# Altium schematic sheet InputsExtras.SchDoc
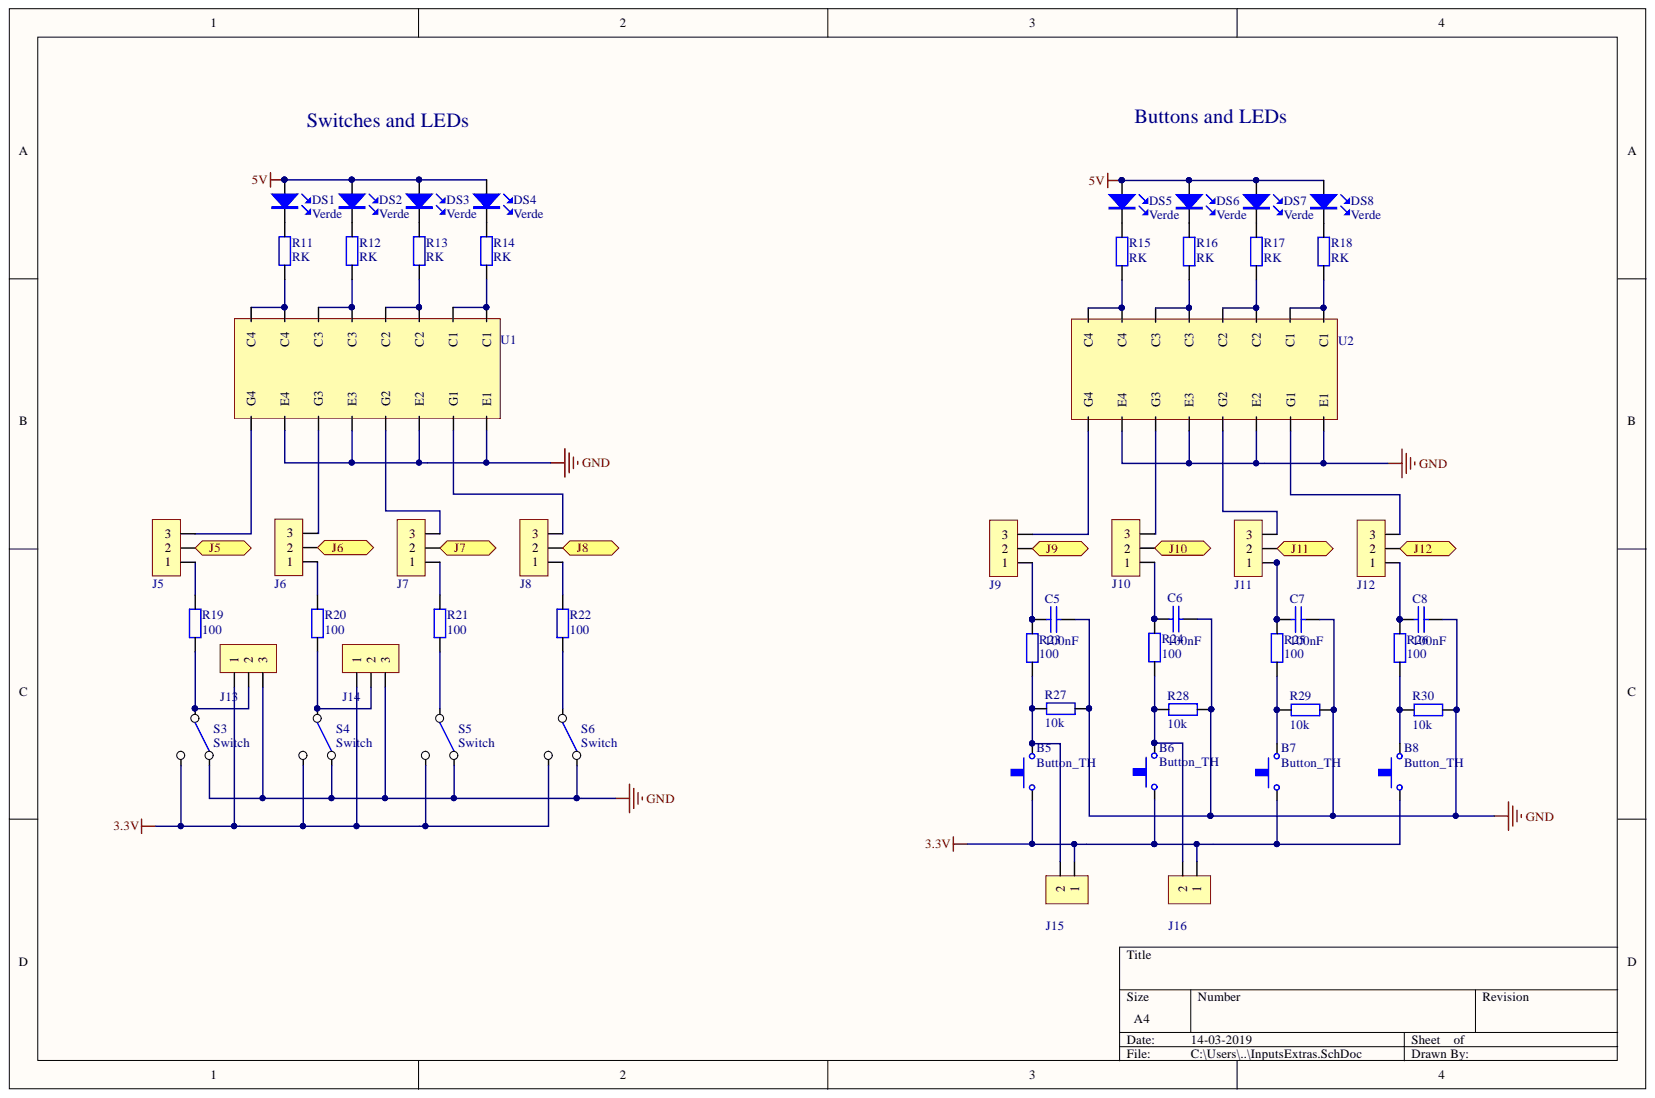
[diagram p0: InputsExtras.SchDoc]

## Parts
B5  Button_TH  [ButtonTH]
B6  Button_TH  [ButtonTH]
B7  Button_TH  [ButtonTH]
B8  Button_TH  [ButtonTH]
C5  100nF  [1206]
C6  100nF  [1206]
C7  100nF  [1206]
C8  100nF  [1206]
DS1  Verde  [LED_100mil]
DS2  Verde  [LED_100mil]
DS3  Verde  [LED_100mil]
DS4  Verde  [LED_100mil]
DS5  Verde  [LED_100mil]
DS6  Verde  [LED_100mil]
DS7  Verde  [LED_100mil]
DS8  Verde  [LED_100mil]
J5  Cleva 2 vias  [con_3_100milis]  (value not drawn)
J6  Cleva 2 vias  [con_3_100milis]  (value not drawn)
J7  Cleva 2 vias  [con_3_100milis]  (value not drawn)
J8  Cleva 2 vias  [con_3_100milis]  (value not drawn)
J9  Cleva 2 vias  [con_3_100milis]  (value not drawn)
J10  Cleva 2 vias  [con_3_100milis]  (value not drawn)
J11  Cleva 2 vias  [con_3_100milis]  (value not drawn)
J12  Cleva 2 vias  [con_3_100milis]  (value not drawn)
J13  Cleva 2 vias  [Con3_KK_256]  (value not drawn)
J14  Cleva 2 vias  [Con3_KK_256]  (value not drawn)
J15  Cleva 2 vias  [Con2_KK_256]  (value not drawn)
J16  Cleva 2 vias  [Con2_KK_256]  (value not drawn)
R11  RK  [1206]
R12  RK  [1206]
R13  RK  [1206]
R14  RK  [1206]
R15  RK  [1206]
R16  RK  [1206]
R17  RK  [1206]
R18  RK  [1206]
R19  100  [1206]
R20  100  [1206]
R21  100  [1206]
R22  100  [1206]
R23  100  [1206]
R24  100  [1206]
R25  100  [1206]
R26  100  [1206]
R27  10k  [1206]
R28  10k  [1206]
R29  10k  [1206]
R30  10k  [1206]
S3  Switch  [SwitchTH_2Pos]
S4  Switch  [SwitchTH_2Pos]
S5  Switch  [SwitchTH_2Pos]
S6  Switch  [SwitchTH_2Pos]
U1  MMPQ3904  [SO-16]  (value not drawn)
U2  MMPQ3904  [SO-16]  (value not drawn)

## Ports
J5, J6, J7, J8, J9, J10, J11, J12

## Nets
3.3V: B5.1 B6.1 B7.1 B8.1 J13.1 J14.1 J15.1 J16.1 S3.2 S4.2 S5.2 S6.2
5V: DS1.A DS2.A DS3.A DS4.A DS5.A DS6.A DS7.A DS8.A
GND: C5.1 C6.1 C7.1 C8.1 J13.3 J14.3 R27.1 R28.1 R29.1 R30.1 S3.0 S4.0 S5.0 S6.0 U1.10(E4) U1.12(E3) U1.14(E2) U1.16(E1) U2.10(E4) U2.12(E3) U2.14(E2) U2.16(E1)
J5: J5.2 ; port J5
J6: J6.2 ; port J6
J7: J7.2 ; port J7
J8: J8.2 ; port J8
J9: J9.2 ; port J9
J10: J10.2 ; port J10
J11: J11.2 ; port J11
J12: J12.2 ; port J12
NetB5_0: B5.0 J15.2 R23.1 R27.2
NetB6_0: B6.0 J16.2 R24.1 R28.2
NetB7_0: B7.0 R25.1 R29.2
NetB8_0: B8.0 R26.1 R30.2
NetC5_2: C5.2 J9.1 R23.2
NetC6_2: C6.2 J10.1 R24.2
NetC7_2: C7.2 J11.1 R25.2
NetC8_2: C8.2 J12.1 R26.2
NetDS1_K: DS1.K R11.1
NetDS2_K: DS2.K R12.1
NetDS3_K: DS3.K R13.1
NetDS4_K: DS4.K R14.1
NetDS5_K: DS5.K R15.1
NetDS6_K: DS6.K R16.1
NetDS7_K: DS7.K R17.1
NetDS8_K: DS8.K R18.1
NetJ5_1: J5.1 R19.2
NetJ5_3: J5.3 U1.9(G4)
NetJ6_1: J6.1 R20.2
NetJ6_3: J6.3 U1.11(G3)
NetJ7_1: J7.1 R21.2
NetJ7_3: J7.3 U1.13(G2)
NetJ8_1: J8.1 R22.2
NetJ8_3: J8.3 U1.15(G1)
NetJ9_3: J9.3 U2.9(G4)
NetJ10_3: J10.3 U2.11(G3)
NetJ11_3: J11.3 U2.13(G2)
NetJ12_3: J12.3 U2.15(G1)
NetJ13_2: J13.2 R19.1 S3.1
NetJ14_2: J14.2 R20.1 S4.1
NetR11_2: R11.2 U1.7(C4) U1.8(C4)
NetR12_2: R12.2 U1.5(C3) U1.6(C3)
NetR13_2: R13.2 U1.3(C2) U1.4(C2)
NetR14_2: R14.2 U1.1(C1) U1.2(C1)
NetR15_2: R15.2 U2.7(C4) U2.8(C4)
NetR16_2: R16.2 U2.5(C3) U2.6(C3)
NetR17_2: R17.2 U2.3(C2) U2.4(C2)
NetR18_2: R18.2 U2.1(C1) U2.2(C1)
NetR21_1: R21.1 S5.1
NetR22_1: R22.1 S6.1

## Library data of the parts
C5-C8 = Condensador: Component Kind: Standard; Description: Condensador; Library Name: SCH_Lib.SchLib
DS1-DS8 = Led: Component Kind: Standard; Library Name: SCH_Lib.SchLib
J5-J14 = Con_3: Component Kind: Standard; Library Name: SCH_Lib.SchLib
J15 J16 = Con_2: Component Kind: Standard; Library Name: SCH_Lib.SchLib
R11-R30 = Resistencia: Potencia: 1/4W; Component Kind: Standard; Description: Resistencia; Library Name: SCH_Lib.SchLib
B5-B8 = Button_TH: Component Kind: Standard; Library Name: SCH_Lib.SchLib
S3-S6 = Switch: Component Kind: Standard; Library Name: SCH_Lib.SchLib
U1 U2 = MMPQ3904: Component Kind: Standard; Library Name: SCH_Lib.SchLib
